# Altium schematic sheet Voeding_bout.SchDoc
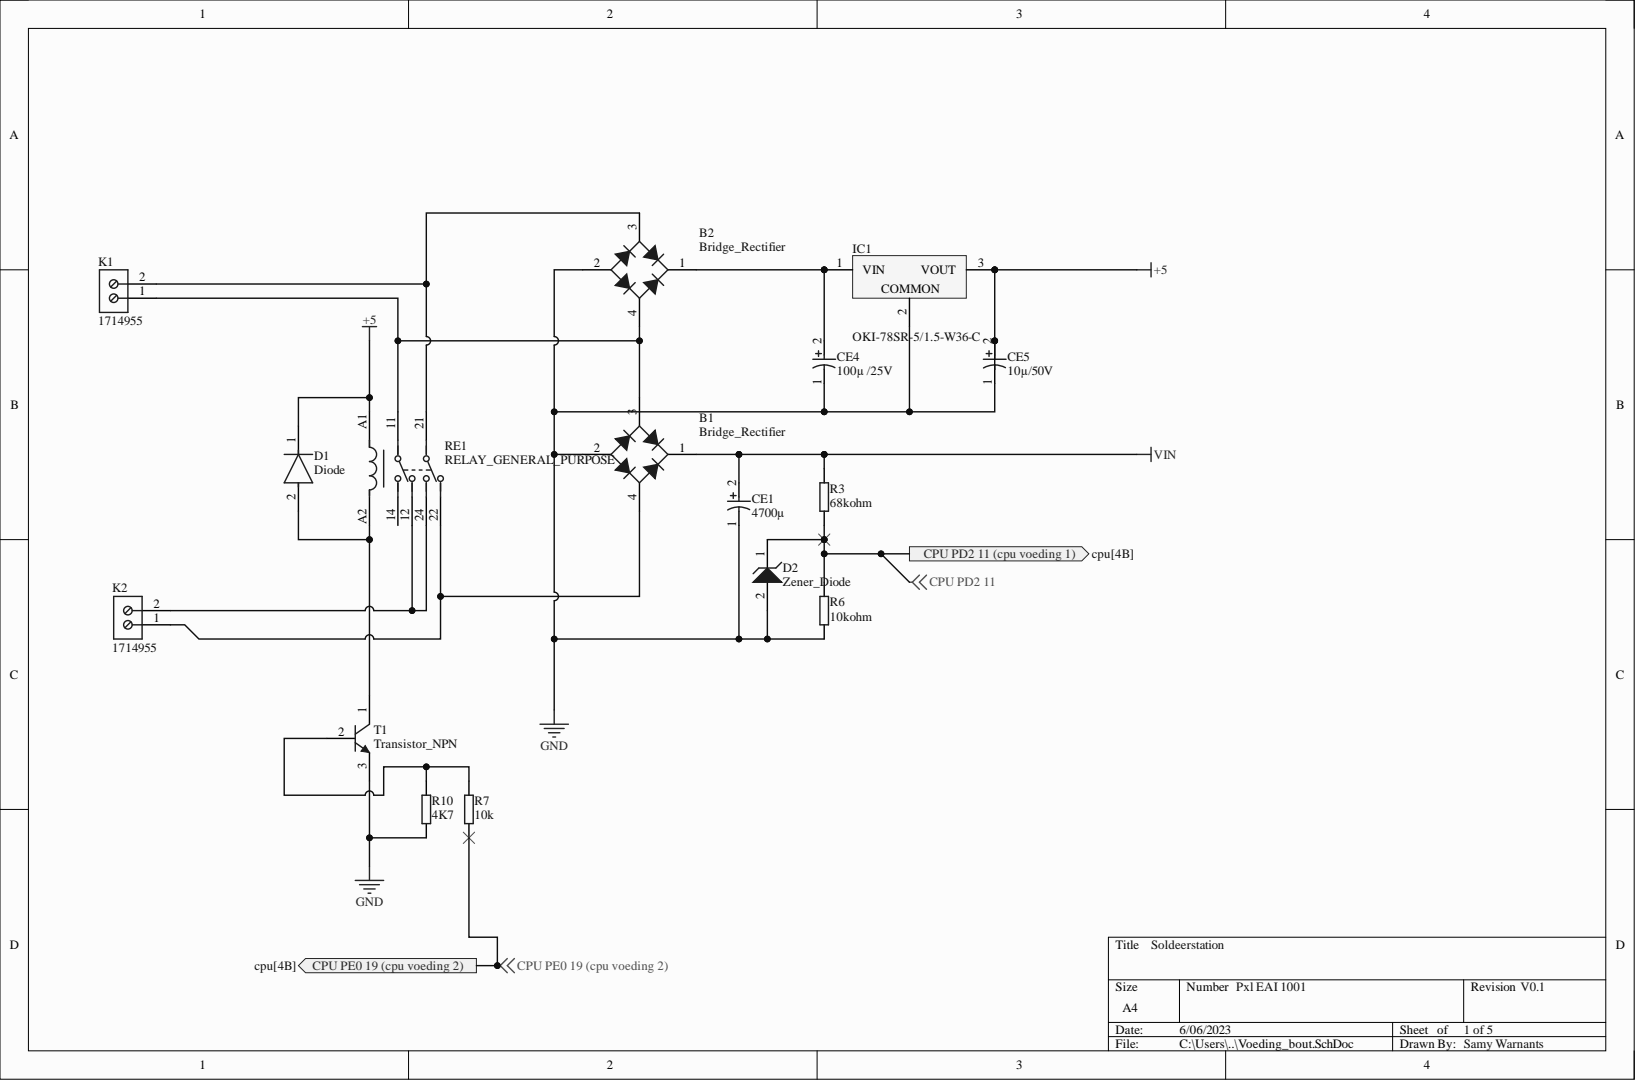
[diagram p0: Voeding_bout.SchDoc]

## Parts
B1  Bridge_Rectifier  [ttf]
B2  Bridge_Rectifier  [ttf]
CE1  4700µ  [CE_D25_L25_plugin]
CE4  100µ /25V  [CE_D8_L10]
CE5  10µ/50V  [CE_D6,3_L4,5]
D1  Diode  [SOD-123FL]
D2  Zener_Diode  [SOD-123FL]
IC1  OKI-78SR-5/1.5-W36-C  [OKI78SR515W36C]
K1  1714955  [PHOE-1714955_V]
K2  1714955  [PHOE-1714955_V]
R3  68kohm  [R_0805_M]
R6  10kohm  [R_0805_M]
R7  10k  [R_0805_M]
R10  4K7  [R_0805_M]
RE1  RELAY_GENERAL_PURPOSE  [RT42]
T1  Transistor_NPN  [SOT_23]

## Ports
CPU PD2 11 (cpu voeding 1), CPU PE0 19 (cpu voeding 2)

## Nets
+5: CE5.2 D1.1 IC1.3 RE1.A1
GND: B1.2 B2.2 CE1.1 CE4.1 CE5.1 D2.2 IC1.2 R6.2 R10.1 T1.3
VIN: B1.1 CE1.2 R3.1
CPU PD2 11: D2.1 R3.2 R6.1 ; port CPU PD2 11 (cpu voeding 1)
CPU PE0 19 (cpu voeding 2): R7.1 ; port CPU PE0 19 (cpu voeding 2)
NetB1_3: B1.3 B2.4 K1.1 RE1.11
NetB1_4: B1.4 K2.1 RE1.22
NetB2_1: B2.1 CE4.2 IC1.1
NetB2_3: B2.3 K1.2 RE1.21
NetD1_2: D1.2 RE1.A2 T1.1
NetK2_2: K2.2 RE1.12 RE1.24
NetR7_2: R7.2 R10.2 T1.2

Not connected: RE1 14

## Library data of the parts
B1 B2 = Bridge_Rectifier: Manufacturer: Diodes Inc; Average Rectified Current-Max: 0.8A; Forward Voltage: 1V; Package Version: 05/2016; Peak Current-Max: 30A; Reverse Current-Max: 5µA; Reverse Voltage-Max [Vrrm]: 600V; Component Kind: Standard; Datasheet URL: http://www.diodes.com/_files/datasheets/ds17003.pdf; Datasheet Version: Rev. 13-2, 12/2010; Manufacturer URL: http://www.diodes.com/; Mounting Technology: SurfaceMount; Package Description: 4-Pin Small Outline Integrated Circuit, Body 4.7 x 3.8 mm, Pitch 2.5 mm; Package Reference: MiniDIP-4; Package URL: http://www.diodes.com/_files/packages/MiniDIP.pdf; Library Name: Soldeerstation_Final.SchLib
CE1 CE4 CE5 = Capacitor_Electrolytic: Manufacturer: Panasonic; Voltage Rating: 25 V; Component Kind: Standard; ComponentLink1Description: Manufacturer URL; ComponentLink1URL: http://www.panasonic.com/; ComponentLink2Description: Datasheet; ComponentLink2URL: http://industrial.panasonic.com/cdbs/www-data/pdf/RDE0000/ABA0000C1181.pdf; DatasheetVersion: 03/2016; Height: 10.2 mm; Lead Pitch: 3.1 mm; Length: 10.2 mm; Max Operating Temperature: 105 degC; Min Operating Temperature: -55 degC; Mount: Surface Mount; Mounting Technology: Surface Mount; Package Quantity: 500; PackageDescription: 2-Pin Electrolytic Aluminum Capacitor, Body 8.3 x 8.3 mm; PackageReference: EEE-FK1E221P; Packaging: Tape and Reel; Pins: 2; REACH SVHC: No SVHC; RoHSCompliant: true; Library Name: Soldeerstation_Final (1).SchLib
D1 = Diode: Manufacturer: Diotec; Manufacturer Part Number: 1N4007; Capacitance: 15pF; Forward Current: 1A; Max Junction Temp: +175°C; Max Repetitive Reverse Voltage: 1000V; Max Surge Forward Current: 27A; Peak Reverse Current: 5uA; Value: 15pF; Automotive: No; Category: Diode; Component Kind: Standard; ComponentLink2Description: Datasheet; ComponentLink2URL: https://datasheet.octopart.com/1N4007-Diotec-datasheet-62346875.pdf; Device Class L1: Discrete Semiconductors; Device Class L2: Diodes; Device Class L3: Rectifier Diodes; Height: 2.8mm; Ipc Land Pattern Name: DIOAD888W100L520D280; Lead Free: Yes; Octopart: https://octopart.com/1n4007-diotec-4040411; Package: 1N4007; Reverse Voltage: 1000V; RoHS: TRUE; Temperature: -50°C to +175°C; Temperature Range High: +175°C; Temperature Range Low: -50°C; Library Name: Soldeerstation_Final.SchLib
D2 = Zener_Diode: Manufacturer: On Semiconductor; PartNumber: 1SMB5920BT3G; Packing: Tape and Reel; VZ Typ (V): 6.2; Component Kind: Standard; ComponentLink1Description: Manufacturer URL; ComponentLink1URL: http://www.onsemi.com/; ComponentLink2Description: Datasheet; ComponentLink2URL: http://www.onsemi.com/pub_link/Collateral/1SMB5913BT3-D.PDF; ComponentLink3Description: Package Specification; ComponentLink3URL: http://www.onsemi.com/pub_link/Collateral/1SMB5913BT3-D.PDF; DatasheetVersion: Rev. 8; Mounting Technology: Surface Mount; PackageDescription: 2-Pin Small Molded Package, J-Bend Lead, Body 4.33 x 3.625 mm; PackageReference: SMB-2-403A-03_H; PackageVersion: Rev. H, 05/2011; RoHS: Pb-Free; Library Name: Soldeerstation_Final.SchLib
IC1 = OKI-78SR-5/1.5-W36-C: Non-Isolated DC/DC Converter, 7.5 W, 7 to 36 V, 1.5 A, -40 to 85 degC, 3-Pin THD, RoHS; Manufacturer: Murata; Power (Watts) - Manufacture Series: 8W; Current - Output (Max): 1.5A; Efficiency: 90.5%; Number of Outputs: 1; Voltage - Input (Max): 36V; Voltage - Input (Min): 7V; Voltage - Output 1: 5V; Applications: ITE(Commercial); Category: PowerSupplies-BoardMount; Component Kind: Standard; Datasheet URL: https://datasheet.ciiva.com/8006/1790439-8006672.pdf; Datasheet Version: Oct-13; Family: DCDCConverters; Features: SCP; Manufacturer URL: http://www.murata.com/; Mounting Type: ThroughHole; Operating Temperature: -40degC~85degC; Package / Case: 3-SIPModule; Packaging: Tube; Power (Watts) - Max: 8W; Series: Okami™OKI-78SR; Size / Dimension: 0.41"Lx0.30"Wx0.65"H(10.4mmx7.6mmx16.5mm); Type: LinearRegulatorReplacement; Voltage - Isolation: -; Voltage - Output 2: -; Voltage - Output 3: -; Library Name: Soldeerstation_Final (1).SchLib
K1 K2 = CMP-2000-05797-1: PC Terminal Block, Pitch 6.35 mm, 1 x 2 Position, Height 21.5 mm, Tail Lenght 5.1 mm, RoHS, Bulk; Manufacturer: Phoenix Contact; Component Kind: Standard; Datasheet URL: http://media.digikey.com/pdf/Data%20Sheets/Phoenix%20Contact%20PDFs/1714955.pdf; Datasheet Version: 02/2010; Library Name: Altium Content Vault; Manufacturer URL: http://www.phoenixcontact.com/; Mounting Technology: ThroughHole; Package Description: PC Terminal Block, Pitch 6.35 mm, 1 x 2 Position, Height 21.5 mm, Tail Lenght 5.1 mm; Package Reference: 1714955
R3 R6 R7 R10 = Resistor: Manufacturer: Vishay Dale; Part Number: CRCW08050000Z0EA; Component Kind: Standard
  R3 R6: Library Name: Soldeerstation_Final.SchLib
  R7 R10: Library Name: Soldeerstation_Final (1).SchLib
RE1 = RELAY_GENERAL_PURPOSE: Manufacturer: TE Connectivity; Manufacturer Part Number: RT424005; Coil Power: 403mW; Coil Resistance: 62Ω; Coil Type: Non-Latching; Coil Voltage: 5V; Current Rating: 8A; Number Of Contacts: 2; Throw Configuration: DPDT; Ambient Temperature Range High: +85°C; Ambient Temperature Range Low: -40°C; Automotive: No; Category: Relay; Component Kind: Standard; ComponentLink2Description: Datasheet; ComponentLink2URL: https://www.te.com/commerce/DocumentDelivery/DDEController?Action=showdoc&DocId=Data+Sheet%7FRT2%7F1014%7Fpdf%7FEnglish%7FENG_DS_RT2_1014.pdf%7F5-1393243-9; Device Class L1: Electromechanical; Device Class L2: Relays; Device Class L3: Power Relays; Digikey Description: RELAY GENERAL PURPOSE DPDT 8A 5V; Height: 15.7mm; Lead Free: Yes; Mouser Description: General Purpose Relay, Rt2 Series, Power, Non Latching, Dpdt, 5 Vdc, 8 A Rohs Compliant: Yes; Octopart: https://octopart.com/5-1393243-9-te+connectivity-41835766; Package: RT424005; RoHS: TRUE; Library Name: Soldeerstation_Final.SchLib
T1 = Transistor_NPN: Manufacturer: On Semiconductor; PartNumber: BC547C; fT Min (MHz): 150; IC Continuous (A): 0.1; Packing: Bulk Box; Polarity: NPN; Component Kind: Standard; ComponentLink1Description: Manufacturer URL; ComponentLink1URL: http://www.onsemi.com/; ComponentLink2Description: Datasheet; ComponentLink2URL: http://www.onsemi.com/pub_link/Collateral/BC546-D.PDF; ComponentLink3Description: Package Specification; ComponentLink3URL: http://www.onsemi.cn/pub_link/Collateral/2N6426-D.PDF; DatasheetVersion: Rev. 7; Mounting Technology: Through Hole; PackageDescription: 3-Pin Transistor Outline, Body 4.825 x 3.685 mm, Pitch 1.27 mm; PackageReference: TO-92-3-29-11_AM_SL; PackageVersion: Rev. AM; RoHS: FALSE; Library Name: Soldeerstation_Final (1).SchLib
